# Altium schematic sheet DC_DC_Buck.SchDoc
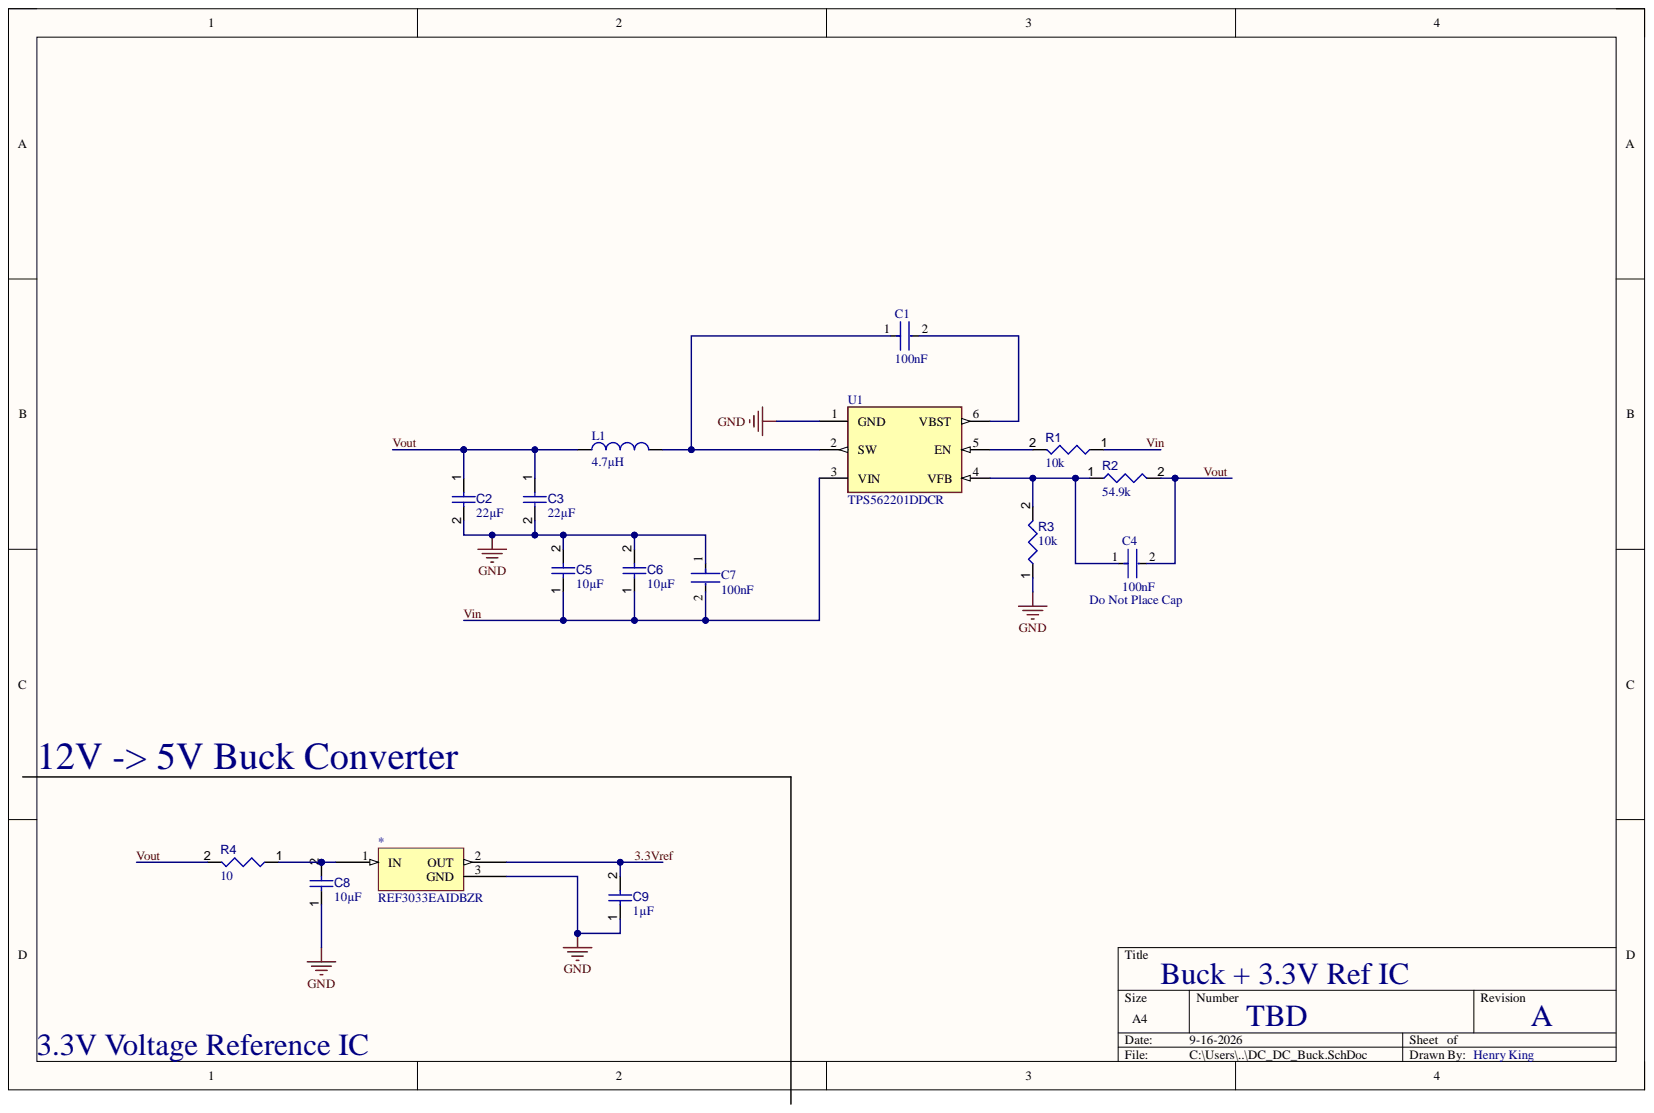
[diagram p0: DC_DC_Buck.SchDoc]

## Parts
*  REF3033EAIDBZR  [SOT95P235X112-3N]
C1  value 100nF  [FP-GRM188-0_1-e0_2_0_5-IPC_B]  (hidden comment: Capacitor 100nF 0603)
C2  value 22µF  [FP-1206-L_3_2_0_3-W_1_6_0-IPC_B]  (hidden comment: 22uf Capacitor 1206)
C3  value 22µF  [FP-1206-L_3_2_0_3-W_1_6_0-IPC_B]  (hidden comment: 22uf Capacitor 1206)
C4  value 100nF  [FP-GRM188-0_1-e0_2_0_5-IPC_B]  (hidden comment: Capacitor 100nF 0603)
C5  value 10µF  [CAPC2012X135N]  (hidden comment: CL21B106KOQNNNE)
C6  value 10µF  [CAPC2012X135N]  (hidden comment: CL21B106KOQNNNE)
C7  value 100nF  [FP-GRM188-0_1-e0_2_0_5-IPC_B]  (hidden comment: Capacitor 100nF 0603)
C8  value 10µF  [CAPC2012X135N]  (hidden comment: CL21B106KOQNNNE)
C9  value 1µF  [CAPC1608X90X35ML15T15]  (hidden comment: Capacitor 1uF +/- 10% 16V 0603)
L1  value 4.7µH  [INDM5252X300N]  (hidden comment: LMLP0506M4R7DTAS)
R1  value 10k  [RESC1608X55X25ML10T15]  (hidden comment: Resistor 10k +/-1% 0603 100 mW)
R2  value 54.9k  [FP-RMCF0603-IPC_B]  (hidden comment: 54.9kohm 0603 Resistor)
R3  value 10k  [RESC1608X55X25ML10T15]  (hidden comment: Resistor 10k +/-1% 0603 100 mW)
R4  value 10  [RESC0402(1005)_L]  (hidden comment: Resistor 10R  +/-1 % 0402 63 mW)
U1  TPS562201DDCR  [FP-DDC0006A-MFG]

## Nets
GND: *.3 C2.2 C3.2 C5.2 C6.2 C7.1 C8.1 C9.1 R3.1 U1.1(GND)
3.3Vref: *.2 C9.2
Vin: C5.1 C6.1 C7.2 R1.1 U1.3(VIN)
Vout: C2.1 C3.1 C4.2 L1.1 R2.2 R4.2
Net*_1: *.1 C8.2 R4.1
NetC1_1: C1.1 L1.2 U1.2(SW)
NetC1_2: C1.2 U1.6(VBST)
NetC4_1: C4.1 R2.1 R3.2 U1.4(VFB)
NetR1_2: R1.2 U1.5(EN)

## Library data of the parts
* = CMP-019-00053-1: 15 ppm°/C drift, 27uA Iq in SOT-23-3 package series voltage reference 3-SOT-23 -40 to 125; Output Voltage: 3.3V; Case/Package: SOT-23; Component Kind: Standard; Max Operating Temperature: 125°C; Min Operating Temperature: -40°C; Pins: 3; Library Name: Henry King
C1 C4 C7 = CMP-001-00084-1: 100V 100nF X7R ±10% 0603 Multilayer Ceramic Capacitors MLCC - SMD/SMT ROHS; Case/Package: 0603; Component Kind: Standard; Max Operating Temperature: 125°C; Min Operating Temperature: -55°C; Mounting Technology: Surface Mount; Pins: 2; Simulation: C_Capacitor_Default; Tolerance: 10%; Voltage Rating: 100V; Library Name: Henry King
C2 C3 = CMP-001-00087-1: CAP CER 22UF 25V X5R 1206 / High Value Multilayer Ceramic Capacitors (High dielectric type); Tolerance: 20%; Case/Package: 1206; Component Kind: Standard; Max Operating Temperature: 85°C; Min Operating Temperature: -55°C; Mounting Technology: Surface Mount; Simulation: TMK316BBJ226_L-T; Voltage Rating: 25V; Library Name: Henry King
C5 C6 C8 = CMP-001-00090-1: 16V 10uF X7R 卤10% 0805 Multilayer Ceramic Capacitors MLCC - SMD/SMT ROHS; Tolerance: 10%; Case/Package: 0805; Component Kind: Standard; Max Operating Temperature: 125°C; Min Operating Temperature: -55°C; Mounting Technology: Surface Mount; Simulation: C_Capacitor_Default; Voltage Rating: 16V; Library Name: Henry King
C9 = CMP-001-00004-6: Chip Capacitor, 1 uF, +/- 10%, 16 V, 0603 (1608 Metric); Capacitor Type: Ceramic; Case/Package: 0603; Component Kind: Standard; Max Operating Temperature: 125°C; Min Operating Temperature: -55°C; Mounting Technology: SM; Pins: 2; RoHS Compliant: True; Tolerance: 10%; Voltage Rating: 16V; Library Name: Henry King
L1 = CMP-006-00022-1: Inductor Power Wirewound 4.7uH 20% 100KHz Ferrite 3.5A 77.5mOhm DCR; Case/Package: 2120; Component Kind: Standard; Max Current Rating: 3.5A; Max Operating Temperature: 155°C; Min Operating Temperature: -55°C; Simulation: L_Inductor_Default; Tolerance: 20%; Library Name: Henry King
R1 R3 = CMP-009-00017-5: Chip Resistor, 10 KOhm, +/- 1%, 01 W, -55 to 155 degC, 0603 (1608 Metric); Power: 100mW; Voltage Rating: 50V; Case/Package: 0603; Component Kind: Standard; Max Operating Temperature: 155°C; Min Operating Temperature: -55°C; Mounting Technology: SM; Pins: 2; RoHS Compliant: True; Simulation: R_Resistor_Default; Tolerance: 1%; Library Name: Henry King
R2 = CMP-009-00180-1: Res Thick Film 0603 54.9K Ohm 1% 0.1W(1/10W) ±100ppm/C Pad SMD Automotive T/R; Voltage Rating: 75V; Case/Package: 0603; Component Kind: Standard; Max Operating Temperature: 155°C; Min Operating Temperature: -55°C; Mounting Technology: Surface Mount; Simulation: R_Resistor_Default; Tolerance: 1%; Library Name: Henry King
R4 = CMP-009-00152-3: Chip Resistor, 10 Ohms, +/-1 %, 63 mW, -55 to 155 degC, 0402; Power: 63mW; Tolerance: 1%; Case/Package: 0402; Component Kind: Standard; Max Operating Temperature: 155°C; Min Operating Temperature: -55°C; Pins: 2; Simulation: R_Resistor_Default; Library Name: Henry King
U1 = CMP-011-00013-2: Buck 580KHz Adjustable SOT-23-THIN SMD mount 1.1mm(height); Manufacturer Lifecycle Status: ACTIVE; Max Duty Cycle: 75%; Max Junction Temperature (Tj): 125°C; Max Output current: 2A; Max Supply Voltage: 17V; Min Input Voltage: 4.5V; Nominal Supply Current: 380µA; Number of Channels: 1; Output Voltage: 7V; Quiescent Current: 400µA; Case/Package: SOT; Component Kind: Standard; Contact Plating: Tin; Height: 1.1mm; Lead Free: Lead Free; Length: 2.9mm; Lifecycle Status: Production; Max Operating Temperature: 125°C; Min Operating Temperature: -40°C; Mounting Technology: Surface Mount; Number of Outputs: 1; Operating Supply Voltage: 17V; Output Current: 2A; Output Type: Adjustable; Pins: 6; RoHS: Compliant; Switching Frequency: 580kHz; Thickness: 870µm; Topology: Buck; Width: 1.6mm; Library Name: Henry King
